# Altium schematic sheet GNSS_MB.SchDoc
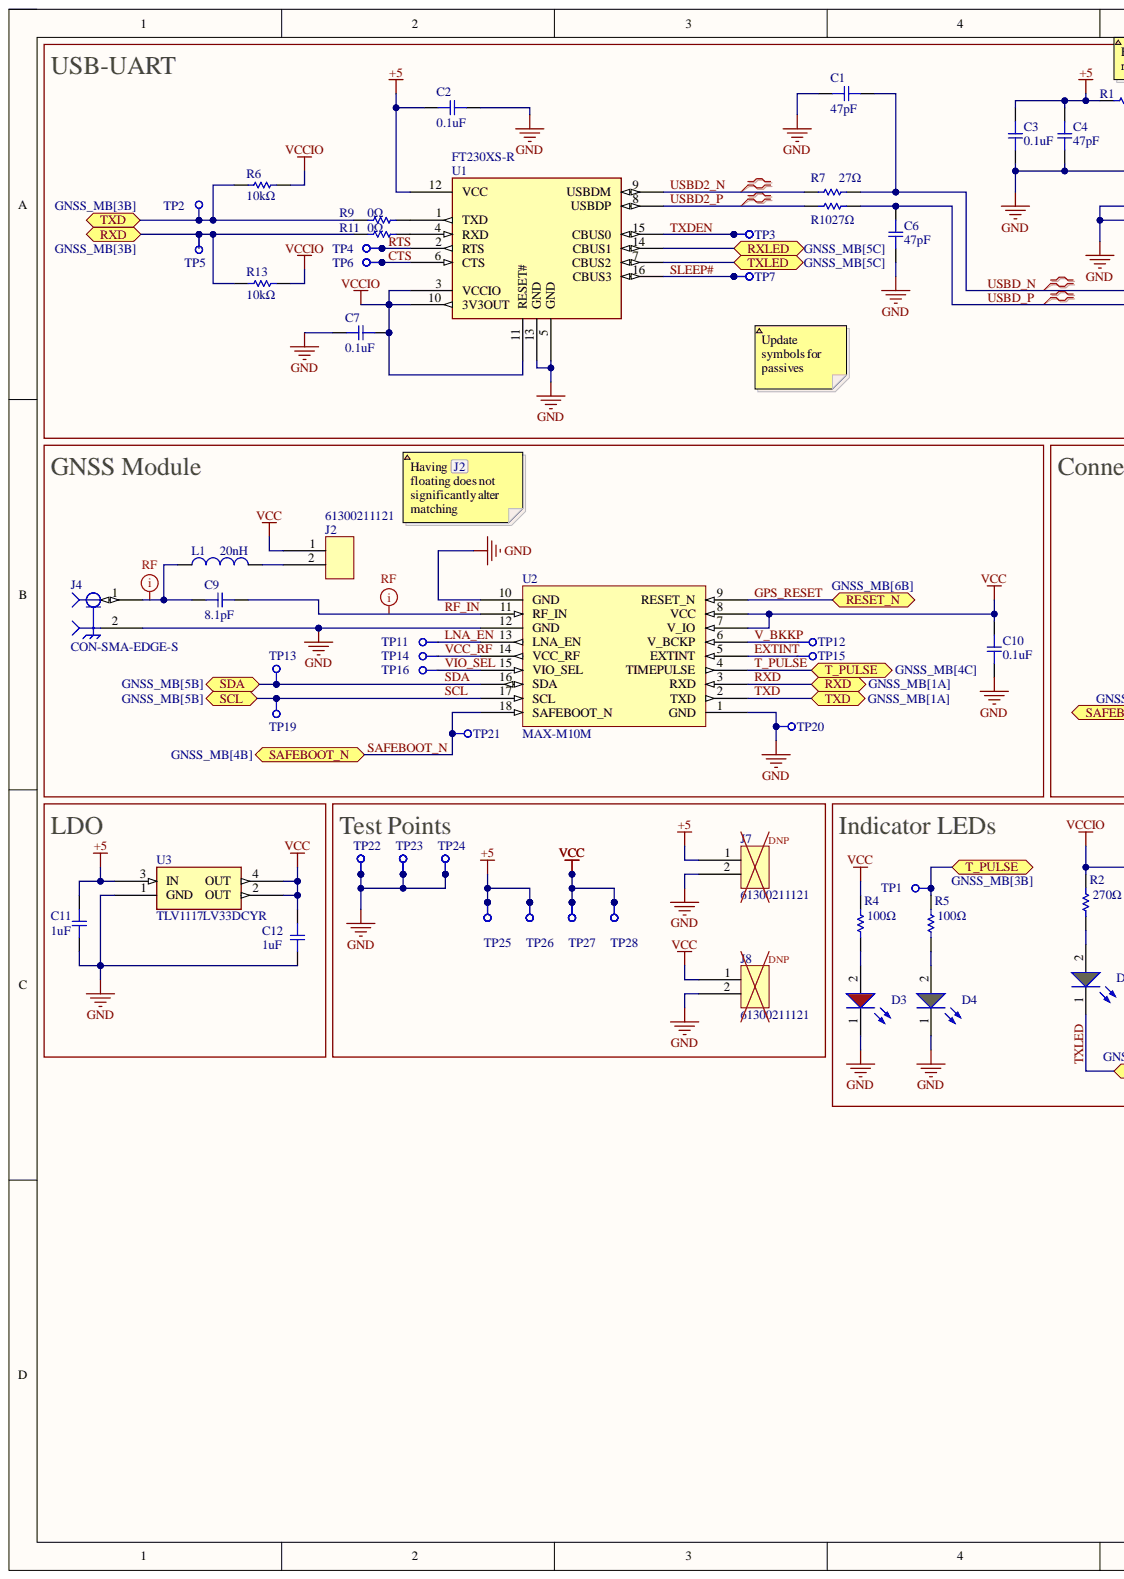
[diagram p0: GNSS_MB.SchDoc, left]
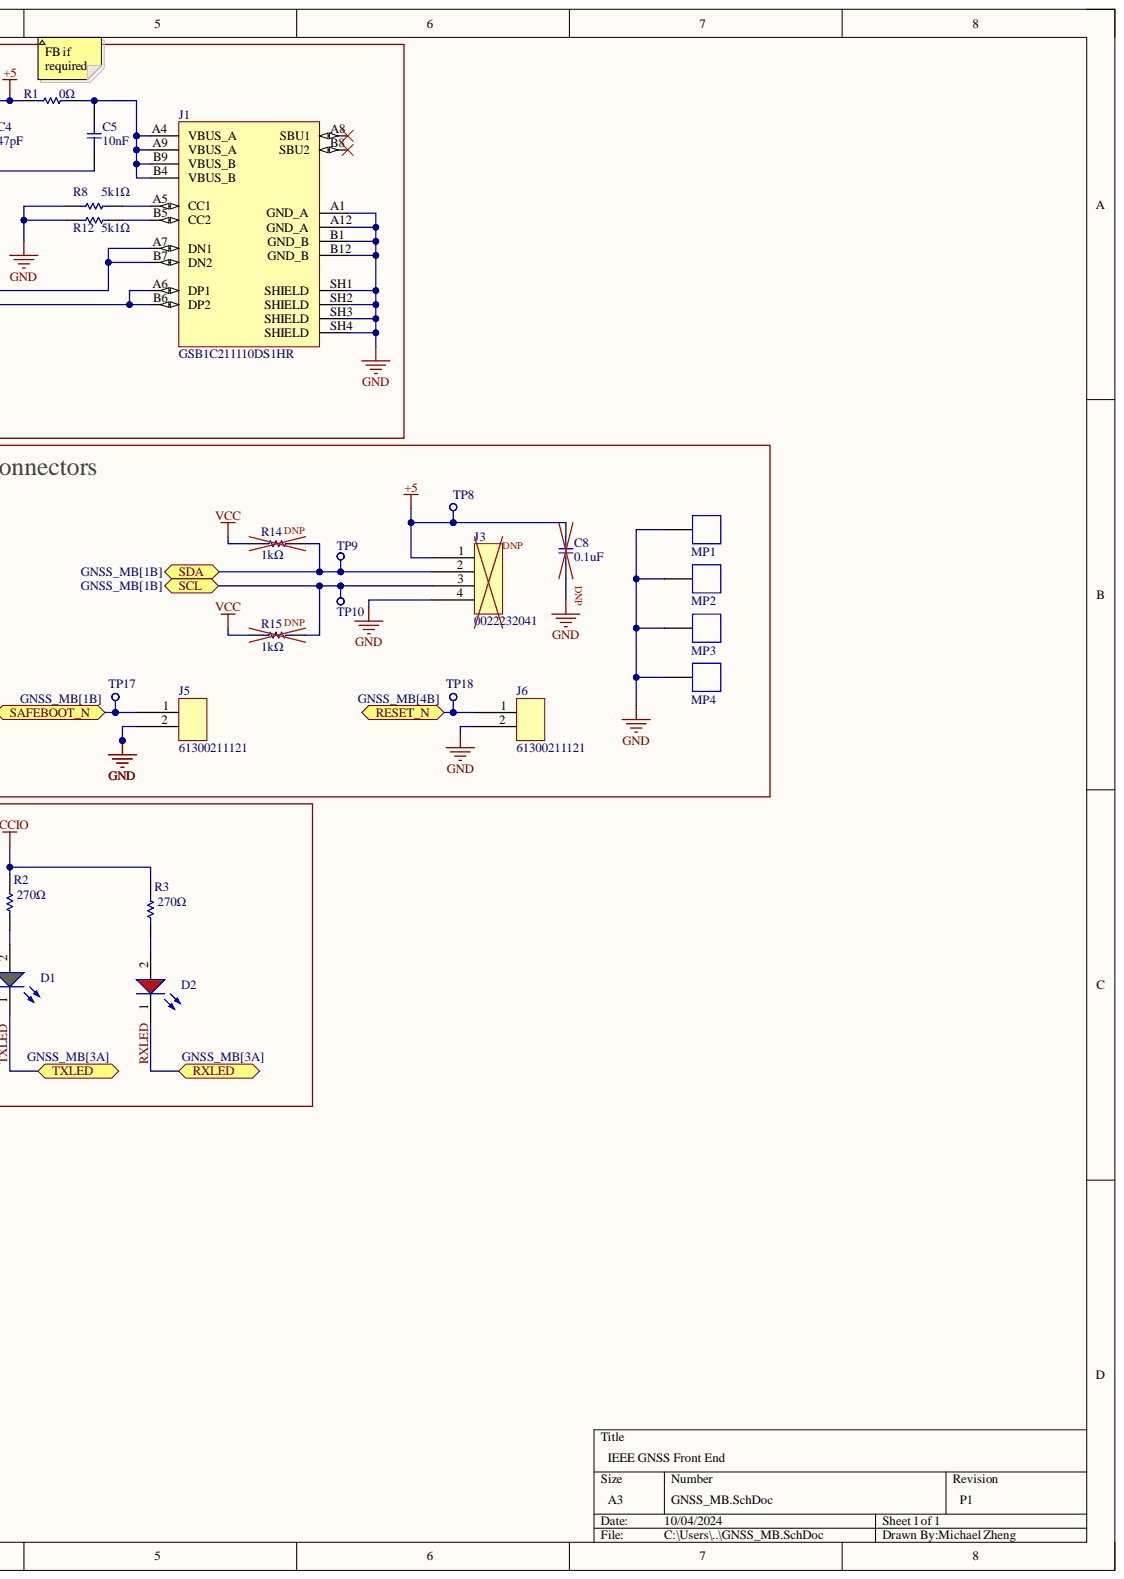
[diagram p1: GNSS_MB.SchDoc, right]

## Parts
C1  Capacitance: 47pF  [CAP_MURATA_0603]  (hidden comment: GCM188L81H104KA57D)
C2  Capacitance: 0.1uF  [CAP_MURATA_0603]  (hidden comment: GCM188L81H104KA57D)
C3  Capacitance: 0.1uF  [CAP_MURATA_0603]  (hidden comment: GCM188L81H104KA57D)
C4  Capacitance: 47pF  [CAP_MURATA_0603]  (hidden comment: GCM188L81H104KA57D)
C5  Capacitance: 10nF  [CAP_MURATA_0603]  (hidden comment: GCM188L81H104KA57D)
C6  Capacitance: 47pF  [CAP_MURATA_0603]  (hidden comment: GCM188L81H104KA57D)
C7  Capacitance: 0.1uF  [CAP_MURATA_0603]  (hidden comment: GCM188L81H104KA57D)
C8  Capacitance: 0.1uF  [CAP_MURATA_0603]  (hidden comment: GCM188L81H104KA57D)
C9  Capacitance: 8.1pF  [CAP_MURATA_0603]  (hidden comment: GCM188L81H104KA57D)
C10  Capacitance: 0.1uF  [CAP_MURATA_0603]  (hidden comment: GCM188L81H104KA57D)
C11  Capacitance: 1uF  [CAP_MURATA_0603]  (hidden comment: GRM188R61A105KA61D)
C12  Capacitance: 1uF  [CAP_MURATA_0603]  (hidden comment: GRM188R61A105KA61D)
D1  Green, 3.2V, 140°  [WL-SMCW - duplicate]  (value not drawn)
D2  Red, 2V, 140°  [WL-SMCW - duplicate]  (value not drawn)
D3  Red, 2V, 140°  [WL-SMCW - duplicate]  (value not drawn)
D4  Green, 3.2V, 140°  [WL-SMCW - duplicate]  (value not drawn)
J1  GSB1C211110DS1HR  [AMPHENOL_GSB1C211110DS1HR]
J2  61300211121  [61300211121]
J3  0022232041  [CONN HEADER VERT 4POS 2.54MM]
J4  CON-SMA-EDGE-S  [RFSOLUTIONS_CON-SMA-EDGE-S]
J5  61300211121  [61300211121]
J6  61300211121  [61300211121]
J7  61300211121  [61300211121]
J8  61300211121  [61300211121]
L1  value 20nH  [INDC1006X60N]  (hidden comment: LQW15AN3N6C10D)
MP1  36900000  [36900000]  (value not drawn)
MP2  36900000  [36900000]  (value not drawn)
MP3  36900000  [36900000]  (value not drawn)
MP4  36900000  [36900000]  (value not drawn)
R1  Resistance: 0Ω  [RC0603JR-070RL]  (hidden comment: RC0603JR-070RL)
R2  Resistance: 270Ω  [RC0603JR-070RL]  (hidden comment: RC0603JR-070RL)
R3  Resistance: 270Ω  [RC0603JR-070RL]  (hidden comment: RC0603JR-070RL)
R4  Resistance: 100Ω  [RC0603JR-070RL]  (hidden comment: RC0603JR-070RL)
R5  Resistance: 100Ω  [RC0603JR-070RL]  (hidden comment: RC0603JR-070RL)
R6  Resistance: 10kΩ  [RC0603JR-070RL]  (hidden comment: RC0603JR-070RL)
R7  Resistance: 27Ω  [RC0603JR-070RL]  (hidden comment: RC0603JR-070RL)
R8  Resistance: 5k1Ω  [RC0603JR-070RL]  (hidden comment: RC0603JR-070RL)
R9  Resistance: 0Ω  [RC0603JR-070RL]  (hidden comment: RC0603JR-070RL)
R10  Resistance: 27Ω  [RC0603JR-070RL]  (hidden comment: RC0603JR-070RL)
R11  Resistance: 0Ω  [RC0603JR-070RL]  (hidden comment: RC0603JR-070RL)
R12  Resistance: 5k1Ω  [RC0603JR-070RL]  (hidden comment: RC0603JR-070RL)
R13  Resistance: 10kΩ  [RC0603JR-070RL]  (hidden comment: RC0603JR-070RL)
R14  Resistance: 1kΩ  [RC0603JR-070RL]  (hidden comment: RC0603JR-070RL)
R15  Resistance: 1kΩ  [RC0603JR-070RL]  (hidden comment: RC0603JR-070RL)
TP1  TEST_POINT  [TEST_POINT]  (value not drawn)
TP2  TEST_POINT  [TEST_POINT]  (value not drawn)
TP3  TEST_POINT  [TEST_POINT]  (value not drawn)
TP4  TEST_POINT  [TEST_POINT]  (value not drawn)
TP5  TEST_POINT  [TEST_POINT]  (value not drawn)
TP6  TEST_POINT  [TEST_POINT]  (value not drawn)
TP7  TEST_POINT  [TEST_POINT]  (value not drawn)
TP8  TEST_POINT  [TEST_POINT]  (value not drawn)
TP9  TEST_POINT  [TEST_POINT]  (value not drawn)
TP10  TEST_POINT  [TEST_POINT]  (value not drawn)
TP11  TEST_POINT  [TEST_POINT]  (value not drawn)
TP12  TEST_POINT  [TEST_POINT]  (value not drawn)
TP13  TEST_POINT  [TEST_POINT]  (value not drawn)
TP14  TEST_POINT  [TEST_POINT]  (value not drawn)
TP15  TEST_POINT  [TEST_POINT]  (value not drawn)
TP16  TEST_POINT  [TEST_POINT]  (value not drawn)
TP17  TEST_POINT  [TEST_POINT]  (value not drawn)
TP18  TEST_POINT  [TEST_POINT]  (value not drawn)
TP19  TEST_POINT  [TEST_POINT]  (value not drawn)
TP20  TEST_POINT  [TEST_POINT]  (value not drawn)
TP21  TEST_POINT  [TEST_POINT]  (value not drawn)
TP22  TEST_POINT  [TEST_POINT]  (value not drawn)
TP23  TEST_POINT  [TEST_POINT]  (value not drawn)
TP24  TEST_POINT  [TEST_POINT]  (value not drawn)
TP25  TEST_POINT  [TEST_POINT]  (value not drawn)
TP26  TEST_POINT  [TEST_POINT]  (value not drawn)
TP27  TEST_POINT  [TEST_POINT]  (value not drawn)
TP28  TEST_POINT  [TEST_POINT]  (value not drawn)
U1  FT230XS-R  [FT230XS-R]
U2  MAX-M10M  [MAX-M10M]
U3  TLV1117LV33DCYR  [TLV1117LV33DCYR]

## Ports
RESET_N, RXD, RXLED, SAFEBOOT_N, SCL, SDA, T_PULSE, TXD, TXLED

## Nets
+5: C2.2 C3.1 C4.1 C8.1 C11.2 J3.1 J7.1 R1.1 TP8.1 TP25.1 TP26.1 U1.12(VCC) U3.3(IN)
GND: C1.1 C2.1 C3.2 C4.2 C5.2 C6.2 C7.2 C8.2 C10.1 C11.1 C12.1 D3.1 D4.1 J1.A1(GND_A) J1.A12(GND_A) J1.B1(GND_B) J1.B12(GND_B) J1.SH1(SHIELD) J1.SH2(SHIELD) J1.SH3(SHIELD) J1.SH4(SHIELD) J3.4 J4.2 J5.2 J6.2 J7.2 J8.2 MP1.1 MP2.1 MP3.1 MP4.1 R8.1 R12.1 TP20.1 TP22.1 TP23.1 TP24.1 U1.5(GND) U1.13(GND) U2.1(GND) U2.10(GND) U2.12(GND) U3.1(GND)
VCC: C10.2 C12.2 J2.1 J8.1 R4.2 R14.2 R15.2 TP27.1 TP28.1 U2.7(V_IO) U2.8(VCC) U3.2(OUT) U3.4(OUT)
VCCIO: C7.1 R2.2 R3.2 R6.2 R13.2 U1.3(VCCIO) U1.10(3V3OUT) U1.11(RESET#)
CTS: TP6.1 U1.6(CTS)
EXTINT: TP15.1 U2.5(EXTINT)
GPS_RESET: J6.1 TP18.1 U2.9(RESET_N) ; port RESET_N
LNA_EN: TP11.1 U2.13(LNA_EN)
RF_IN: C9.2 U2.11(RF_IN)
RTS: TP4.1 U1.2(RTS)
RXD: R11.1 R13.1 TP5.1 U2.3(RXD) ; port RXD
RXLED: D2.1 U1.14(CBUS1) ; port RXLED
SAFEBOOT_N: J5.1 TP17.1 TP21.1 U2.18(SAFEBOOT_N) ; port SAFEBOOT_N
SCL: J3.3 R15.1 TP10.1 TP19.1 U2.17(SCL) ; port SCL
SDA: J3.2 R14.1 TP9.1 TP13.1 U2.16(SDA) ; port SDA
SLEEP#: TP7.1 U1.16(CBUS3)
T_PULSE: R5.2 TP1.1 U2.4(TIMEPULSE) ; port T_PULSE
TXD: R6.1 R9.1 TP2.1 U2.2(TXD) ; port TXD
TXDEN: TP3.1 U1.15(CBUS0)
TXLED: D1.1 U1.7(CBUS2) ; port TXLED
USBD2_N: R7.1 U1.9(USBDM)
USBD2_P: R10.1 U1.8(USBDP)
USBD_N: C1.2 J1.A7(DN1) J1.B7(DN2) R7.2
USBD_P: C6.1 J1.A6(DP1) J1.B6(DP2) R10.2
V_BKKP: TP12.1 U2.6(V_BCKP)
VCC_RF: TP14.1 U2.14(VCC_RF)
VIO_SEL: TP16.1 U2.15(VIO_SEL)
NetC5_1: C5.1 J1.A4(VBUS_A) J1.A9(VBUS_A) J1.B4(VBUS_B) J1.B9(VBUS_B) R1.2
NetC9_1: C9.1 J4.1 L1.1
NetD1_2: D1.2 R2.1
NetD2_2: D2.2 R3.1
NetD3_2: D3.2 R4.1
NetD4_2: D4.2 R5.1
NetJ1_A5: J1.A5(CC1) R8.2
NetJ1_B5: J1.B5(CC2) R12.2
NetJ2_2: J2.2 L1.2
NetR9_2: R9.2 U1.1(TXD)
NetR11_2: R11.2 U1.4(RXD)

Not connected: J1 A8(SBU1) B8(SBU2)

## Library data of the parts
C1-C10 = GCM188L81H104KA57D: CAP CER 0.1UF 50V X8L 0603; Component Kind: Standard; Library Name: Symbols.SchLib
C11 C12 = GRM188R61A105KA61D: CAP CER 1UF 10V X5R 0603; Component Kind: Standard; Library Name: Symbols.SchLib
D1 D4 = 150060GS75000: WL-SMCW Mono-color Chip LED Waterclear, SMT, size 0603, Green, 3.2V, 140°; Manufacturer: Wurth Elektronik; Case/Size Code: 0603; Chip Technology: InGaN; Dominant Wavelength: 525nm; Luminous Intensity: 430mcd; Peak Wavelength: 515nm; Category: Signal LEDs; Component Kind: Standard; ComponentLink1Description: Website Link; ComponentLink1URL: https://www.we-online.com/redexpert/article/150060GS75000?ad; ComponentLink2Description: Datasheet Link; ComponentLink2URL: https://www.we-online.com/redexpert/spec/150060GS75000?ad; Emitting Color: Green; Forward Voltage: 3.2V; Height: 0.7mm; Length: 1.6mm; Library Name: Symbols.SchLib; Manufacturer Part Number: 150060GS75000; Match Code: WL-SMCW; Mount: Surface Mount; Operating Temperature Max: 85°C; Operating Temperature Min: -40°C; Packaging: Tape and Reel; Viewing Angle: 140°; Width: 0.8mm
D2 D3 = 150060RS75000: WL-SMCW Mono-color Chip LED Waterclear, SMT, size 0603, Red, 2V, 140°; Manufacturer: Wurth Elektronik; Case/Size Code: 0603; Chip Technology: AlInGaP; Dominant Wavelength: 625nm; Luminous Intensity: 250mcd; Peak Wavelength: 630nm; Category: Signal LEDs; Component Kind: Standard; ComponentLink1Description: Website Link; ComponentLink1URL: https://www.we-online.com/redexpert/article/150060RS75000?ad; ComponentLink2Description: Datasheet Link; ComponentLink2URL: https://www.we-online.com/redexpert/spec/150060RS75000?ad; Emitting Color: Red; Forward Voltage: 2V; Height: 0.7mm; Length: 1.6mm; Library Name: Symbols.SchLib; Manufacturer Part Number: 150060RS75000; Match Code: WL-SMCW; Mount: Surface Mount; Operating Temperature Max: 85°C; Operating Temperature Min: -40°C; Packaging: Tape and Reel; Viewing Angle: 140°; Width: 0.8mm
J1 = GSB1C211110DS1HR: USB2.0, Type C, Top mount, Center Height 1.78mm, Dual Row DIP; MF: Amphenol Canada; Check_prices: https://www.snapeda.com/parts/GSB1C211110DS1HR/Amphenol/view-part/?ref=eda; Component Kind: Standard; Library Name: Symbols.SchLib; MP: GSB1C211110DS1HR; Package: None; SnapEDA_Link: https://www.snapeda.com/parts/GSB1C211110DS1HR/Amphenol/view-part/?ref=snap
J2 J5-J8 = 61300211121: CONN HEADER VERT 2POS 2.54MM; Component Kind: Standard; Library Name: Symbols.SchLib
J3 = 0022232041: CONN HEADER VERT 4POS 2.54MM; Component Kind: Standard; Library Name: Symbols.SchLib
J4 = CON-SMA-EDGE-S: CONN SMA JACK STR EDGE MNT; Component Kind: Standard; Library Name: Symbols.SchLib
L1 = LQW15AN3N6C10D: FIXED IND 3.6NH 900MA 40 MOHM; Availability: Unavailable; Component Kind: Standard; Library Name: Symbols.SchLib; MP: LQW15AN3N6C10D; Package: None; Price: None
MP1-MP4 = 36900000: WE-SHC Shielding Cabinet, Clip, Tin Plated, Tape and Reel, 6.5x0.8x1.27mm; Manufacturer: Wurth Elektronik; Base Material: Steel; Category: EMC Shielding; Component Kind: Standard; ComponentLink1Description: Website Link; ComponentLink1URL: https://www.we-online.com/redexpert/article/36900000?ad; ComponentLink2Description: Datasheet Link; ComponentLink2URL: https://www.we-online.com/redexpert/spec/36900000?ad; Height: 1.27mm; Length: 6.5mm; Library Name: Symbols.SchLib; Manufacturer Part Number: 36900000; Match Code: WE-SHC; Mount: Surface Mount; Operating Temperature Max: 125°C; Operating Temperature Min: -40°C; Packaging: Tape and Reel; Plating: Tin Plated; Type: Clip; Width: 0.8mm
R1-R15 = RC0603JR-070RL: Chip Resistor, 0 Ohm, +/- 5%, 0.1 W, -55 to 155 degC, 0603 (1608 Metric), RoHS, Tape and Reel; Manufacturer: Yageo; Power Rating: 100mW; Temperature Coefficient: 200ppm/degreesC; Voltage Rating: 50V; Case Code (Imperial): 0603; Case Code (Metric): 1608; Case/Package: 0603; Component Kind: Standard; Composition: ThickFilm; Contact Plating: Tin; Datasheet URL: http://www.yageo.com/NewPortal/yageodocoutput?fileName=/pdf/R-Chip/PYu-RC_Group_51_RoHS_L_5.pdf; Datasheet Version: Rev. 5, 10/2016; Depth: 0.8mm; Height: 0.45mm; Lead Free: LeadFree; Length: 1.6mm; Library Name: Symbols.SchLib; Manufacturer URL: http://www.yageo.com/; Max Operating Temperature: 155degC; Military Standard: Not; Min Operating Temperature: -55degC; Number of Pins: 2; Package Description: 2-Pin Surface Mount Device, Body 1.6 x 0.8 mm; Package Quantity: 5000; Package Reference: 0603; Packaging: TapeandReel; Radiation Hardening: No; REACH SVHC: NoSVHC; RoHSCompliant: true; Termination: SMD/SMT; Tolerance: 5%; Voltage Rating (DC): 50V; Width: 0.8mm
TP1-TP28 = TEST_POINT: TEST POINT 2MM; Component Kind: Standard; Library Name: Symbols.SchLib
U1 = FT230XS-R: USB FULL SPEED TO SERIAL UART IC; Component Kind: Standard; Library Name: Symbols.SchLib
U2 = MAX-M10M: GNSS LCC RX MOD, ROM, CRYSTAL; Component Kind: Standard; Library Name: Symbols.SchLib
U3 = TLV1117LV33DCYR: IC REG LINEAR 3.3V 1A SOT223-4; Component Kind: Standard; Library Name: Symbols.SchLib
